# Altium schematic sheet backplane.SchDoc
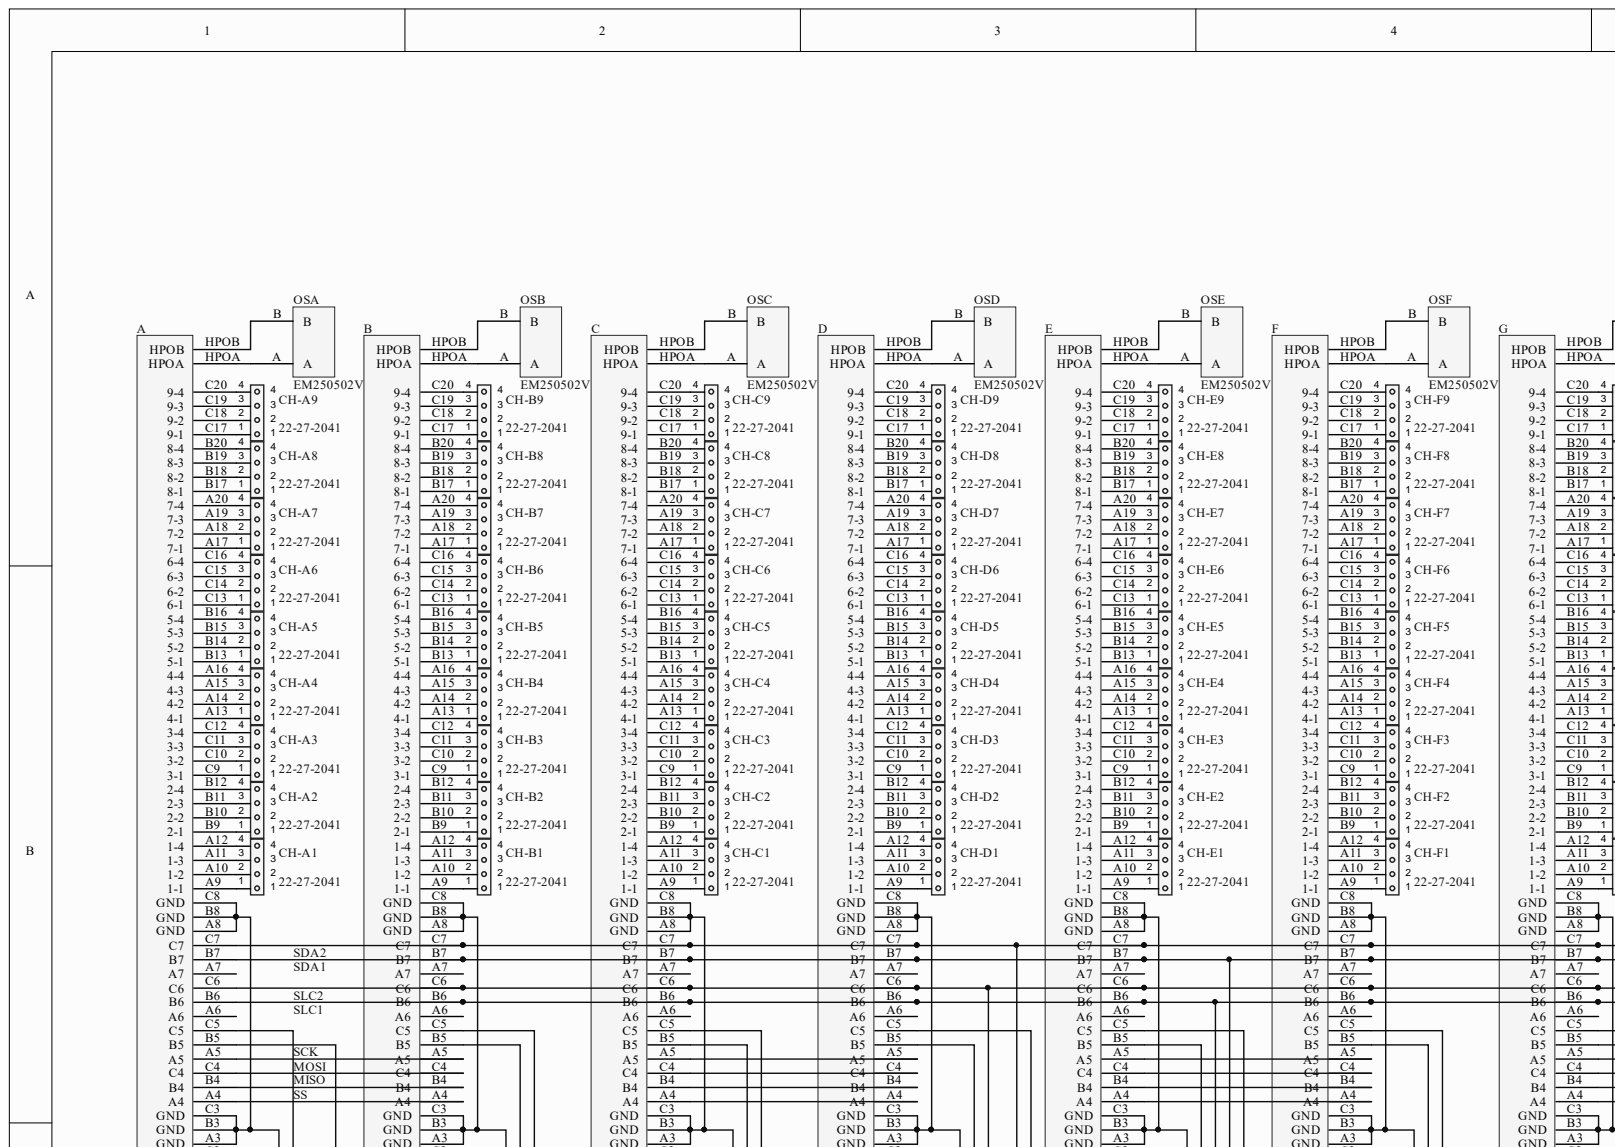
[diagram p0: backplane.SchDoc, top left]
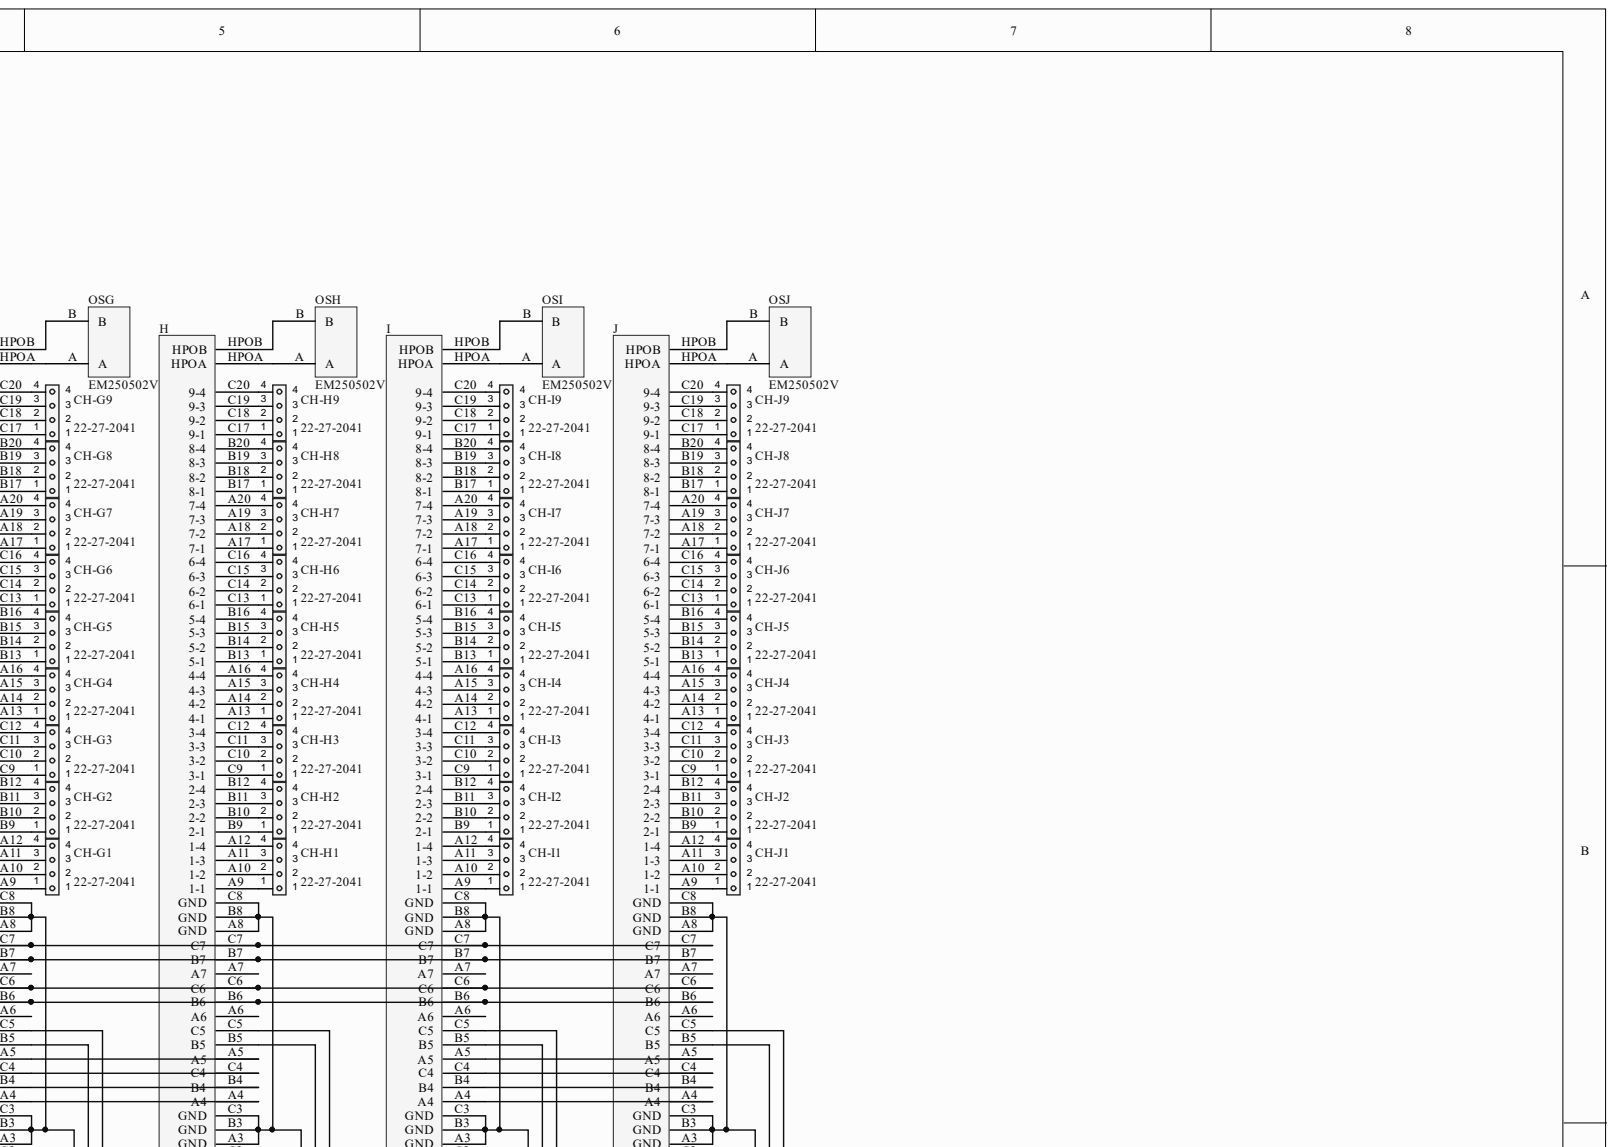
[diagram p1: backplane.SchDoc, top right]
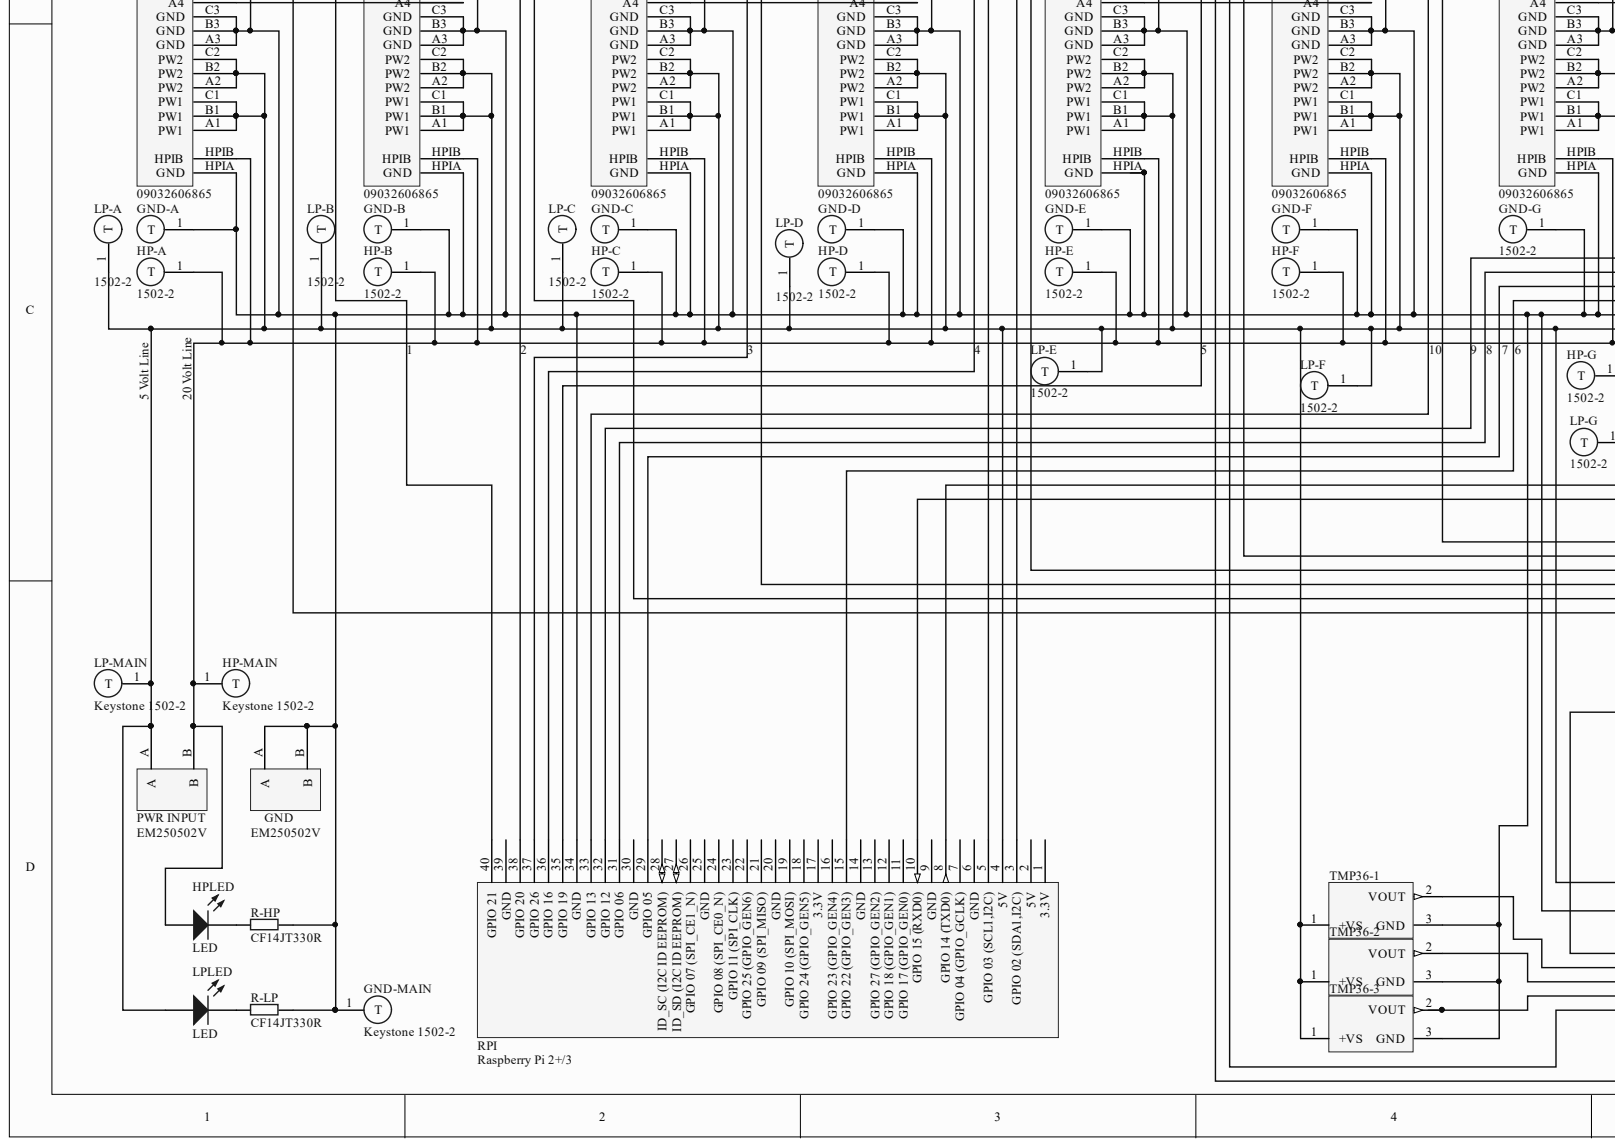
[diagram p2: backplane.SchDoc, bottom left]
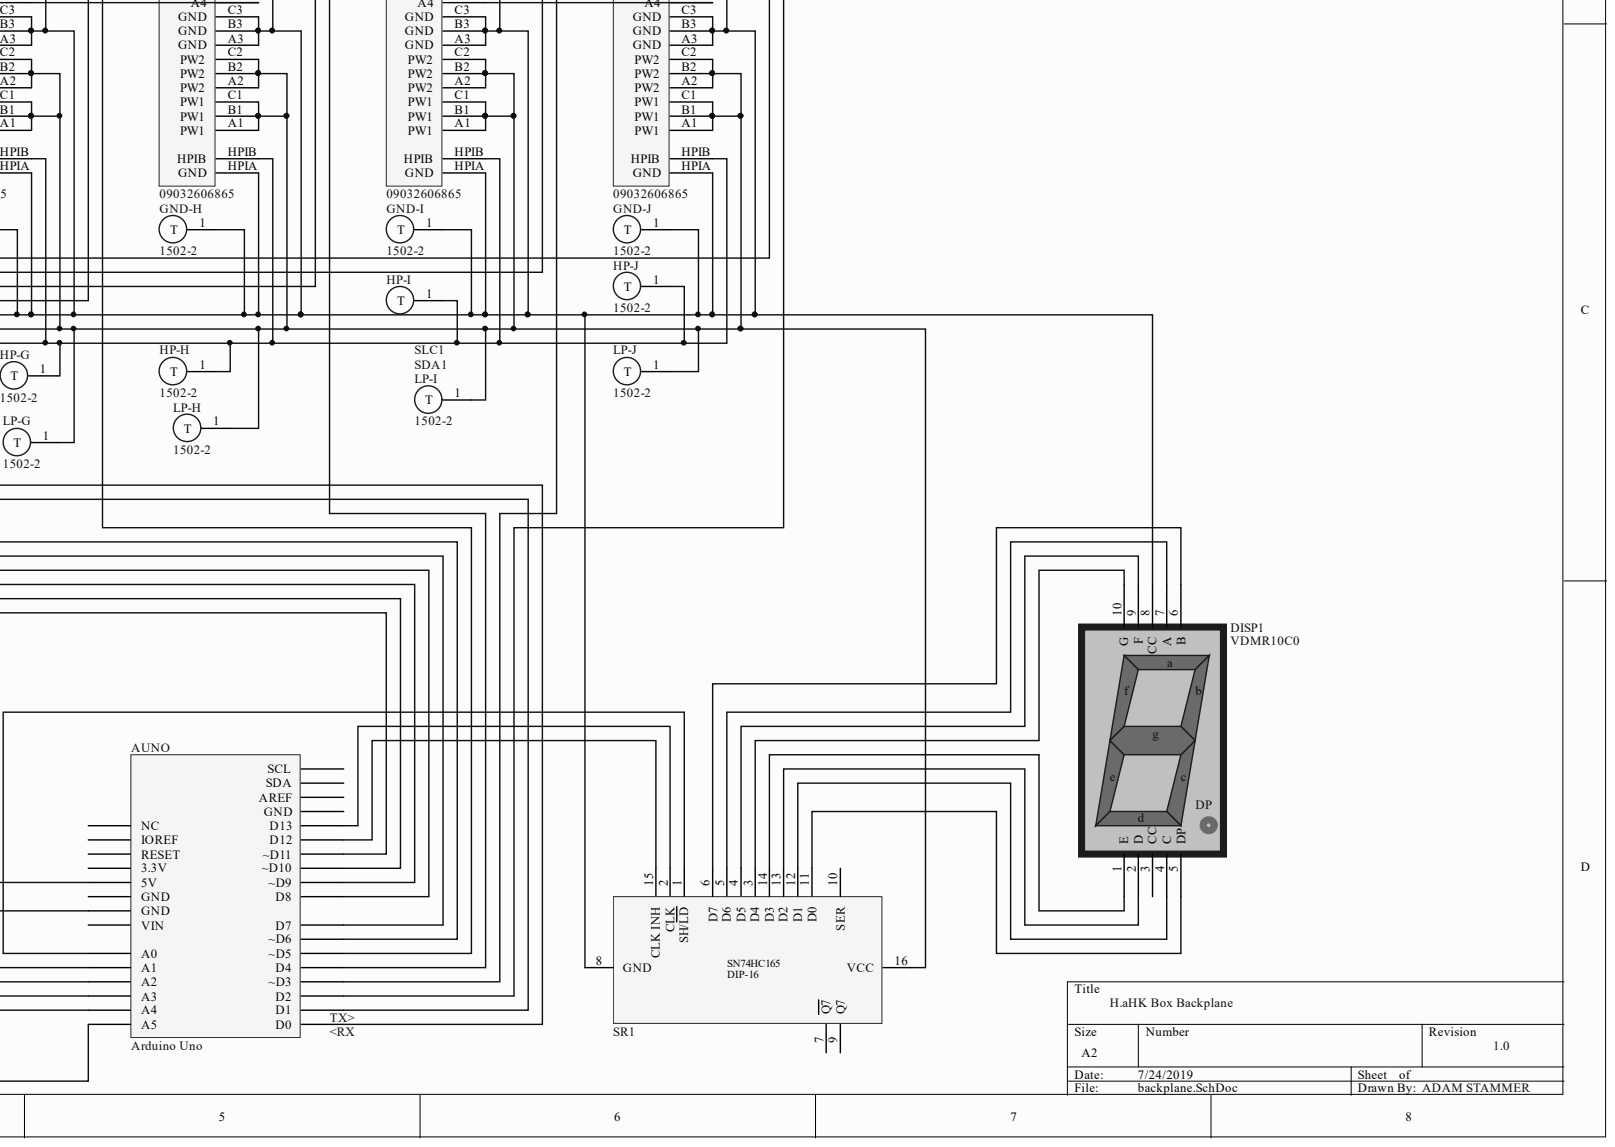
[diagram p3: backplane.SchDoc, bottom right]

## Parts
A  09032606865  [PCBComponent_1 - duplicate]
AUNO  Arduino Uno  [PCB-5e3n8s0ey5jqr2f9nncc-1]
B  09032606865  [PCBComponent_1 - duplicate]
C  09032606865  [PCBComponent_1 - duplicate]
CH-A1  22-27-2041  [PCB-kaiyohh0lgufhypq6v61-1]
CH-A2  22-27-2041  [PCB-kaiyohh0lgufhypq6v61-1]
CH-A3  22-27-2041  [PCB-kaiyohh0lgufhypq6v61-1]
CH-A4  22-27-2041  [PCB-kaiyohh0lgufhypq6v61-1]
CH-A5  22-27-2041  [PCB-kaiyohh0lgufhypq6v61-1]
CH-A6  22-27-2041  [PCB-kaiyohh0lgufhypq6v61-1]
CH-A7  22-27-2041  [PCB-kaiyohh0lgufhypq6v61-1]
CH-A8  22-27-2041  [PCB-kaiyohh0lgufhypq6v61-1]
CH-A9  22-27-2041  [PCB-kaiyohh0lgufhypq6v61-1]
CH-B1  22-27-2041  [PCB-kaiyohh0lgufhypq6v61-1]
CH-B2  22-27-2041  [PCB-kaiyohh0lgufhypq6v61-1]
CH-B3  22-27-2041  [PCB-kaiyohh0lgufhypq6v61-1]
CH-B4  22-27-2041  [PCB-kaiyohh0lgufhypq6v61-1]
CH-B5  22-27-2041  [PCB-kaiyohh0lgufhypq6v61-1]
CH-B6  22-27-2041  [PCB-kaiyohh0lgufhypq6v61-1]
CH-B7  22-27-2041  [PCB-kaiyohh0lgufhypq6v61-1]
CH-B8  22-27-2041  [PCB-kaiyohh0lgufhypq6v61-1]
CH-B9  22-27-2041  [PCB-kaiyohh0lgufhypq6v61-1]
CH-C1  22-27-2041  [PCB-kaiyohh0lgufhypq6v61-1]
CH-C2  22-27-2041  [PCB-kaiyohh0lgufhypq6v61-1]
CH-C3  22-27-2041  [PCB-kaiyohh0lgufhypq6v61-1]
CH-C4  22-27-2041  [PCB-kaiyohh0lgufhypq6v61-1]
CH-C5  22-27-2041  [PCB-kaiyohh0lgufhypq6v61-1]
CH-C6  22-27-2041  [PCB-kaiyohh0lgufhypq6v61-1]
CH-C7  22-27-2041  [PCB-kaiyohh0lgufhypq6v61-1]
CH-C8  22-27-2041  [PCB-kaiyohh0lgufhypq6v61-1]
CH-C9  22-27-2041  [PCB-kaiyohh0lgufhypq6v61-1]
CH-D1  22-27-2041  [PCB-kaiyohh0lgufhypq6v61-1]
CH-D2  22-27-2041  [PCB-kaiyohh0lgufhypq6v61-1]
CH-D3  22-27-2041  [PCB-kaiyohh0lgufhypq6v61-1]
CH-D4  22-27-2041  [PCB-kaiyohh0lgufhypq6v61-1]
CH-D5  22-27-2041  [PCB-kaiyohh0lgufhypq6v61-1]
CH-D6  22-27-2041  [PCB-kaiyohh0lgufhypq6v61-1]
CH-D7  22-27-2041  [PCB-kaiyohh0lgufhypq6v61-1]
CH-D8  22-27-2041  [PCB-kaiyohh0lgufhypq6v61-1]
CH-D9  22-27-2041  [PCB-kaiyohh0lgufhypq6v61-1]
CH-E1  22-27-2041  [PCB-kaiyohh0lgufhypq6v61-1]
CH-E2  22-27-2041  [PCB-kaiyohh0lgufhypq6v61-1]
CH-E3  22-27-2041  [PCB-kaiyohh0lgufhypq6v61-1]
CH-E4  22-27-2041  [PCB-kaiyohh0lgufhypq6v61-1]
CH-E5  22-27-2041  [PCB-kaiyohh0lgufhypq6v61-1]
CH-E6  22-27-2041  [PCB-kaiyohh0lgufhypq6v61-1]
CH-E7  22-27-2041  [PCB-kaiyohh0lgufhypq6v61-1]
CH-E8  22-27-2041  [PCB-kaiyohh0lgufhypq6v61-1]
CH-E9  22-27-2041  [PCB-kaiyohh0lgufhypq6v61-1]
CH-F1  22-27-2041  [PCB-kaiyohh0lgufhypq6v61-1]
CH-F2  22-27-2041  [PCB-kaiyohh0lgufhypq6v61-1]
CH-F3  22-27-2041  [PCB-kaiyohh0lgufhypq6v61-1]
CH-F4  22-27-2041  [PCB-kaiyohh0lgufhypq6v61-1]
CH-F5  22-27-2041  [PCB-kaiyohh0lgufhypq6v61-1]
CH-F6  22-27-2041  [PCB-kaiyohh0lgufhypq6v61-1]
CH-F7  22-27-2041  [PCB-kaiyohh0lgufhypq6v61-1]
CH-F8  22-27-2041  [PCB-kaiyohh0lgufhypq6v61-1]
CH-F9  22-27-2041  [PCB-kaiyohh0lgufhypq6v61-1]
CH-G1  22-27-2041  [PCB-kaiyohh0lgufhypq6v61-1]
CH-G2  22-27-2041  [PCB-kaiyohh0lgufhypq6v61-1]
CH-G3  22-27-2041  [PCB-kaiyohh0lgufhypq6v61-1]
CH-G4  22-27-2041  [PCB-kaiyohh0lgufhypq6v61-1]
CH-G5  22-27-2041  [PCB-kaiyohh0lgufhypq6v61-1]
CH-G6  22-27-2041  [PCB-kaiyohh0lgufhypq6v61-1]
CH-G7  22-27-2041  [PCB-kaiyohh0lgufhypq6v61-1]
CH-G8  22-27-2041  [PCB-kaiyohh0lgufhypq6v61-1]
CH-G9  22-27-2041  [PCB-kaiyohh0lgufhypq6v61-1]
CH-H1  22-27-2041  [PCB-kaiyohh0lgufhypq6v61-1]
CH-H2  22-27-2041  [PCB-kaiyohh0lgufhypq6v61-1]
CH-H3  22-27-2041  [PCB-kaiyohh0lgufhypq6v61-1]
CH-H4  22-27-2041  [PCB-kaiyohh0lgufhypq6v61-1]
CH-H5  22-27-2041  [PCB-kaiyohh0lgufhypq6v61-1]
CH-H6  22-27-2041  [PCB-kaiyohh0lgufhypq6v61-1]
CH-H7  22-27-2041  [PCB-kaiyohh0lgufhypq6v61-1]
CH-H8  22-27-2041  [PCB-kaiyohh0lgufhypq6v61-1]
CH-H9  22-27-2041  [PCB-kaiyohh0lgufhypq6v61-1]
CH-I1  22-27-2041  [PCB-kaiyohh0lgufhypq6v61-1]
CH-I2  22-27-2041  [PCB-kaiyohh0lgufhypq6v61-1]
CH-I3  22-27-2041  [PCB-kaiyohh0lgufhypq6v61-1]
CH-I4  22-27-2041  [PCB-kaiyohh0lgufhypq6v61-1]
CH-I5  22-27-2041  [PCB-kaiyohh0lgufhypq6v61-1]
CH-I6  22-27-2041  [PCB-kaiyohh0lgufhypq6v61-1]
CH-I7  22-27-2041  [PCB-kaiyohh0lgufhypq6v61-1]
CH-I8  22-27-2041  [PCB-kaiyohh0lgufhypq6v61-1]
CH-I9  22-27-2041  [PCB-kaiyohh0lgufhypq6v61-1]
CH-J1  22-27-2041  [PCB-kaiyohh0lgufhypq6v61-1]
CH-J2  22-27-2041  [PCB-kaiyohh0lgufhypq6v61-1]
CH-J3  22-27-2041  [PCB-kaiyohh0lgufhypq6v61-1]
CH-J4  22-27-2041  [PCB-kaiyohh0lgufhypq6v61-1]
CH-J5  22-27-2041  [PCB-kaiyohh0lgufhypq6v61-1]
CH-J6  22-27-2041  [PCB-kaiyohh0lgufhypq6v61-1]
CH-J7  22-27-2041  [PCB-kaiyohh0lgufhypq6v61-1]
CH-J8  22-27-2041  [PCB-kaiyohh0lgufhypq6v61-1]
CH-J9  22-27-2041  [PCB-kaiyohh0lgufhypq6v61-1]
D  09032606865  [PCBComponent_1 - duplicate]
DISP1  VDMR10C0  [PCBComponent_1 - duplicate]
E  09032606865  [PCBComponent_1 - duplicate]
F  09032606865  [PCBComponent_1 - duplicate]
G  09032606865  [PCBComponent_1 - duplicate]
GND  EM250502V  [PCB-u2v43o1ftwqs3duy389i-1]
GND-A  1502-2  [PCB-3pxxqie2fct8emy2m3uy-1]
GND-B  1502-2  [PCB-3pxxqie2fct8emy2m3uy-1]
GND-C  1502-2  [PCB-3pxxqie2fct8emy2m3uy-1]
GND-D  1502-2  [PCB-3pxxqie2fct8emy2m3uy-1]
GND-E  1502-2  [PCB-3pxxqie2fct8emy2m3uy-1]
GND-F  1502-2  [PCB-3pxxqie2fct8emy2m3uy-1]
GND-G  1502-2  [PCB-3pxxqie2fct8emy2m3uy-1]
GND-H  1502-2  [PCB-3pxxqie2fct8emy2m3uy-1]
GND-I  1502-2  [PCB-3pxxqie2fct8emy2m3uy-1]
GND-J  1502-2  [PCB-3pxxqie2fct8emy2m3uy-1]
GND-MAIN  Keystone 1502-2  Manufacturer Part Number: 1502-2  [PCB-3pxxqie2fct8emy2m3uy-1]
H  09032606865  [PCBComponent_1 - duplicate]
HP-A  1502-2  [PCB-3pxxqie2fct8emy2m3uy-1]
HP-B  1502-2  [PCB-3pxxqie2fct8emy2m3uy-1]
HP-C  1502-2  [PCB-3pxxqie2fct8emy2m3uy-1]
HP-D  1502-2  [PCB-3pxxqie2fct8emy2m3uy-1]
HP-E  1502-2  [PCB-3pxxqie2fct8emy2m3uy-1]
HP-F  1502-2  [PCB-3pxxqie2fct8emy2m3uy-1]
HP-G  1502-2  [PCB-3pxxqie2fct8emy2m3uy-1]
HP-H  1502-2  [PCB-3pxxqie2fct8emy2m3uy-1]
HP-I  1502-2  [PCB-3pxxqie2fct8emy2m3uy-1]
HP-J  1502-2  [PCB-3pxxqie2fct8emy2m3uy-1]
HP-MAIN  Keystone 1502-2  Manufacturer Part Number: 1502-2  [PCB-3pxxqie2fct8emy2m3uy-1]
HPLED  LED  [PCB-t8a8bkv5t5yzrq5f3vco-1]
I  09032606865  [PCBComponent_1 - duplicate]
J  09032606865  [PCBComponent_1 - duplicate]
LP-A  1502-2  [PCB-3pxxqie2fct8emy2m3uy-1]
LP-B  1502-2  [PCB-3pxxqie2fct8emy2m3uy-1]
LP-C  1502-2  [PCB-3pxxqie2fct8emy2m3uy-1]
LP-D  1502-2  [PCB-3pxxqie2fct8emy2m3uy-1]
LP-E  1502-2  [PCB-3pxxqie2fct8emy2m3uy-1]
LP-F  1502-2  [PCB-3pxxqie2fct8emy2m3uy-1]
LP-G  1502-2  [PCB-3pxxqie2fct8emy2m3uy-1]
LP-H  1502-2  [PCB-3pxxqie2fct8emy2m3uy-1]
LP-I  1502-2  [PCB-3pxxqie2fct8emy2m3uy-1]
LP-J  1502-2  [PCB-3pxxqie2fct8emy2m3uy-1]
LP-MAIN  Keystone 1502-2  Manufacturer Part Number: 1502-2  [PCB-3pxxqie2fct8emy2m3uy-1]
LPLED  LED  [PCB-t8a8bkv5t5yzrq5f3vco-1]
OSA  EM250502V  [PCB-u2v43o1ftwqs3duy389i-1]
OSB  EM250502V  [PCB-u2v43o1ftwqs3duy389i-1]
OSC  EM250502V  [PCB-u2v43o1ftwqs3duy389i-1]
OSD  EM250502V  [PCB-u2v43o1ftwqs3duy389i-1]
OSE  EM250502V  [PCB-u2v43o1ftwqs3duy389i-1]
OSF  EM250502V  [PCB-u2v43o1ftwqs3duy389i-1]
OSG  EM250502V  [PCB-u2v43o1ftwqs3duy389i-1]
OSH  EM250502V  [PCB-u2v43o1ftwqs3duy389i-1]
OSI  EM250502V  [PCB-u2v43o1ftwqs3duy389i-1]
OSJ  EM250502V  [PCB-u2v43o1ftwqs3duy389i-1]
PWR_INPUT  EM250502V  [PCB-u2v43o1ftwqs3duy389i-1]
R-HP  CF14JT330R  [PCB-2034312-1]
R-LP  CF14JT330R  [PCB-2034312-1]
RPI  Raspberry Pi 2+/3  [Header Only]
SR1  SYM-384ff145283ee788-5  [PCB-v75ktvxv322zbbdwrb5y-1]  (value not drawn)
TMP36-1  TMP36GT9  [ADI-T-3]  (value not drawn)
TMP36-2  TMP36GT9  [ADI-T-3]  (value not drawn)
TMP36-3  TMP36GT9  [ADI-T-3]  (value not drawn)

## Nets
NetA_A1: A.A1(PW1) A.A2(PW2) A.B1(PW1) A.B2(PW2) A.C1(PW1) A.C2(PW2) AUNO.5V B.A1(PW1) B.A2(PW2) B.B1(PW1) B.B2(PW2) B.C1(PW1) B.C2(PW2) C.A1(PW1) C.A2(PW2) C.B1(PW1) C.B2(PW2) C.C1(PW1) C.C2(PW2) D.A1(PW1) D.A2(PW2) D.B1(PW1) D.B2(PW2) D.C1(PW1) D.C2(PW2) E.A1(PW1) E.A2(PW2) E.B1(PW1) E.B2(PW2) E.C1(PW1) E.C2(PW2) F.A1(PW1) F.A2(PW2) F.B1(PW1) F.B2(PW2) F.C1(PW1) F.C2(PW2) G.A1(PW1) G.A2(PW2) G.B1(PW1) G.B2(PW2) G.C1(PW1) G.C2(PW2) H.A1(PW1) H.A2(PW2) H.B1(PW1) H.B2(PW2) H.C1(PW1) H.C2(PW2) I.A1(PW1) I.A2(PW2) I.B1(PW1) I.B2(PW2) I.C1(PW1) I.C2(PW2) J.A1(PW1) J.A2(PW2) J.B1(PW1) J.B2(PW2) J.C1(PW1) J.C2(PW2) LP-A.1 LP-B.1 LP-C.1 LP-D.1 LP-E.1 LP-F.1 LP-G.1 LP-H.1 LP-I.1 LP-J.1 LP-MAIN.1 LPLED.1 PWR_INPUT.A RPI.4(5V) SR1.16(VCC) TMP36-1.1 TMP36-2.1 TMP36-3.1
NetA_A3: A.A3(GND) A.A8(GND) A.B3(GND) A.B8(GND) A.C3(GND) A.C8(GND) A.HPIA(GND) AUNO.GND2(GND) B.A3(GND) B.A8(GND) B.B3(GND) B.B8(GND) B.C3(GND) B.C8(GND) B.HPIA(GND) C.A3(GND) C.A8(GND) C.B3(GND) C.B8(GND) C.C3(GND) C.C8(GND) C.HPIA(GND) D.A3(GND) D.A8(GND) D.B3(GND) D.B8(GND) D.C3(GND) D.C8(GND) D.HPIA(GND) DISP1.8(CC) E.A3(GND) E.A8(GND) E.B3(GND) E.B8(GND) E.C3(GND) E.C8(GND) E.HPIA(GND) F.A3(GND) F.A8(GND) F.B3(GND) F.B8(GND) F.C3(GND) F.C8(GND) F.HPIA(GND) G.A3(GND) G.A8(GND) G.B3(GND) G.B8(GND) G.C3(GND) G.C8(GND) G.HPIA(GND) GND.A GND.B GND-A.1 GND-B.1 GND-C.1 GND-D.1 GND-E.1 GND-F.1 GND-G.1 GND-H.1 GND-I.1 GND-J.1 GND-MAIN.1 H.A3(GND) H.A8(GND) H.B3(GND) H.B8(GND) H.C3(GND) H.C8(GND) H.HPIA(GND) I.A3(GND) I.A8(GND) I.B3(GND) I.B8(GND) I.C3(GND) I.C8(GND) I.HPIA(GND) J.A3(GND) J.A8(GND) J.B3(GND) J.B8(GND) J.C3(GND) J.C8(GND) J.HPIA(GND) R-HP.2 R-LP.2 RPI.34(GND) SR1.8(GND) TMP36-1.3 TMP36-2.3 TMP36-3.3
NetA_A4: A.A4 B.A4
NetA_A5: A.A5 B.A5
NetA_A9: A.A9(1-1) CH-A1.1
NetA_A10: A.A10(1-2) CH-A1.2
NetA_A11: A.A11(1-3) CH-A1.3
NetA_A12: A.A12(1-4) CH-A1.4
NetA_A13: A.A13(4-1) CH-A4.1
NetA_A14: A.A14(4-2) CH-A4.2
NetA_A15: A.A15(4-3) CH-A4.3
NetA_A16: A.A16(4-4) CH-A4.4
NetA_A17: A.A17(7-1) CH-A7.1
NetA_A18: A.A18(7-2) CH-A7.2
NetA_A19: A.A19(7-3) CH-A7.3
NetA_A20: A.A20(7-4) CH-A7.4
NetA_B4: A.B4 B.B4
NetA_B5: A.B5 RPI.40(GPIO_21)
NetA_B6: A.B6 AUNO.A5 B.B6 C.B6 D.B6 E.B6 F.B6 G.B6 H.B6 I.B6 J.B6
NetA_B7: A.B7 AUNO.A4 B.B7 C.B7 D.B7 E.B7 F.B7 G.B7 H.B7 I.B7 J.B7
NetA_B9: A.B9(2-1) CH-A2.1
NetA_B10: A.B10(2-2) CH-A2.2
NetA_B11: A.B11(2-3) CH-A2.3
NetA_B12: A.B12(2-4) CH-A2.4
NetA_B13: A.B13(5-1) CH-A5.1
NetA_B14: A.B14(5-2) CH-A5.2
NetA_B15: A.B15(5-3) CH-A5.3
NetA_B16: A.B16(5-4) CH-A5.4
NetA_B17: A.B17(8-1) CH-A8.1
NetA_B18: A.B18(8-2) CH-A8.2
NetA_B19: A.B19(8-3) CH-A8.3
NetA_B20: A.B20(8-4) CH-A8.4
NetA_C4: A.C4 B.C4
NetA_C5: A.C5 AUNO.D11(~D11)
NetA_C6: A.C6 B.C6 C.C6 D.C6 E.C6 F.C6 G.C6 H.C6 I.C6 J.C6 RPI.5(GPIO_03_(SCL1,I2C))
NetA_C7: A.C7 B.C7 C.C7 D.C7 E.C7 F.C7 G.C7 H.C7 I.C7 J.C7 RPI.3(GPIO_02_(SDA1,I2C))
NetA_C9: A.C9(3-1) CH-A3.1
NetA_C10: A.C10(3-2) CH-A3.2
NetA_C11: A.C11(3-3) CH-A3.3
NetA_C12: A.C12(3-4) CH-A3.4
NetA_C13: A.C13(6-1) CH-A6.1
NetA_C14: A.C14(6-2) CH-A6.2
NetA_C15: A.C15(6-3) CH-A6.3
NetA_C16: A.C16(6-4) CH-A6.4
NetA_C17: A.C17(9-1) CH-A9.1
NetA_C18: A.C18(9-2) CH-A9.2
NetA_C19: A.C19(9-3) CH-A9.3
NetA_C20: A.C20(9-4) CH-A9.4
NetA_HPIB: A.HPIB B.HPIB C.HPIB D.HPIB E.HPIB F.HPIB G.HPIB H.HPIB HP-A.1 HP-B.1 HP-C.1 HP-D.1 HP-E.1 HP-F.1 HP-G.1 HP-H.1 HP-I.1 HP-J.1 HP-MAIN.1 HPLED.1 I.HPIB J.HPIB PWR_INPUT.B
NetA_HPOA: A.HPOA OSA.A
NetA_HPOB: A.HPOB OSA.B
NetAUNO_A0: AUNO.A0 SR1.1(SH/LD)
NetAUNO_A1: AUNO.A1 TMP36-1.2
NetAUNO_A2: AUNO.A2 TMP36-2.2
NetAUNO_A3: AUNO.A3 TMP36-3.2
NetAUNO_D0: AUNO.D0 RPI.8(GPIO_14_(TXD0))
NetAUNO_D1: AUNO.D1 RPI.10(GPIO_15_(RXD0))
NetAUNO_D2: AUNO.D2 J.C5
NetAUNO_D3: AUNO.D3(~D3) I.C5
NetAUNO_D4: AUNO.D4 H.C5
NetAUNO_D5: AUNO.D5(~D5) G.C5
NetAUNO_D6: AUNO.D6(~D6) F.C5
NetAUNO_D7: AUNO.D7 E.C5
NetAUNO_D8: AUNO.D8 D.C5
NetAUNO_D9: AUNO.D9(~D9) C.C5
NetAUNO_D10: AUNO.D10(~D10) B.C5
NetAUNO_D12: AUNO.D12 SR1.15(CLK_INH)
NetAUNO_D13: AUNO.D13 SR1.2(CLK)
NetB_A9: B.A9(1-1) CH-B1.1
NetB_A10: B.A10(1-2) CH-B1.2
NetB_A11: B.A11(1-3) CH-B1.3
NetB_A12: B.A12(1-4) CH-B1.4
NetB_A13: B.A13(4-1) CH-B4.1
NetB_A14: B.A14(4-2) CH-B4.2
NetB_A15: B.A15(4-3) CH-B4.3
NetB_A16: B.A16(4-4) CH-B4.4
NetB_A17: B.A17(7-1) CH-B7.1
NetB_A18: B.A18(7-2) CH-B7.2
NetB_A19: B.A19(7-3) CH-B7.3
NetB_A20: B.A20(7-4) CH-B7.4
NetB_B5: B.B5 RPI.38(GPIO_20)
NetB_B9: B.B9(2-1) CH-B2.1
NetB_B10: B.B10(2-2) CH-B2.2
NetB_B11: B.B11(2-3) CH-B2.3
NetB_B12: B.B12(2-4) CH-B2.4
NetB_B13: B.B13(5-1) CH-B5.1
NetB_B14: B.B14(5-2) CH-B5.2
NetB_B15: B.B15(5-3) CH-B5.3
NetB_B16: B.B16(5-4) CH-B5.4
NetB_B17: B.B17(8-1) CH-B8.1
NetB_B18: B.B18(8-2) CH-B8.2
NetB_B19: B.B19(8-3) CH-B8.3
NetB_B20: B.B20(8-4) CH-B8.4
NetB_C9: B.C9(3-1) CH-B3.1
NetB_C10: B.C10(3-2) CH-B3.2
NetB_C11: B.C11(3-3) CH-B3.3
NetB_C12: B.C12(3-4) CH-B3.4
NetB_C13: B.C13(6-1) CH-B6.1
NetB_C14: B.C14(6-2) CH-B6.2
NetB_C15: B.C15(6-3) CH-B6.3
NetB_C16: B.C16(6-4) CH-B6.4
NetB_C17: B.C17(9-1) CH-B9.1
NetB_C18: B.C18(9-2) CH-B9.2
NetB_C19: B.C19(9-3) CH-B9.3
NetB_C20: B.C20(9-4) CH-B9.4
NetB_HPOA: B.HPOA OSB.A
NetB_HPOB: B.HPOB OSB.B
NetC_A4: C.A4 D.A4
NetC_A5: C.A5 D.A5
NetC_A9: C.A9(1-1) CH-C1.1
NetC_A10: C.A10(1-2) CH-C1.2
NetC_A11: C.A11(1-3) CH-C1.3
NetC_A12: C.A12(1-4) CH-C1.4
NetC_A13: C.A13(4-1) CH-C4.1
NetC_A14: C.A14(4-2) CH-C4.2
NetC_A15: C.A15(4-3) CH-C4.3
NetC_A16: C.A16(4-4) CH-C4.4
NetC_A17: C.A17(7-1) CH-C7.1
NetC_A18: C.A18(7-2) CH-C7.2
NetC_A19: C.A19(7-3) CH-C7.3
NetC_A20: C.A20(7-4) CH-C7.4
NetC_B4: C.B4 D.B4
NetC_B5: C.B5 RPI.37(GPIO_26)
NetC_B9: C.B9(2-1) CH-C2.1
NetC_B10: C.B10(2-2) CH-C2.2
NetC_B11: C.B11(2-3) CH-C2.3
NetC_B12: C.B12(2-4) CH-C2.4
NetC_B13: C.B13(5-1) CH-C5.1
NetC_B14: C.B14(5-2) CH-C5.2
NetC_B15: C.B15(5-3) CH-C5.3
NetC_B16: C.B16(5-4) CH-C5.4
NetC_B17: C.B17(8-1) CH-C8.1
NetC_B18: C.B18(8-2) CH-C8.2
NetC_B19: C.B19(8-3) CH-C8.3
NetC_B20: C.B20(8-4) CH-C8.4
NetC_C4: C.C4 D.C4
NetC_C9: C.C9(3-1) CH-C3.1
NetC_C10: C.C10(3-2) CH-C3.2
NetC_C11: C.C11(3-3) CH-C3.3
NetC_C12: C.C12(3-4) CH-C3.4
NetC_C13: C.C13(6-1) CH-C6.1
NetC_C14: C.C14(6-2) CH-C6.2
NetC_C15: C.C15(6-3) CH-C6.3
NetC_C16: C.C16(6-4) CH-C6.4
NetC_C17: C.C17(9-1) CH-C9.1
NetC_C18: C.C18(9-2) CH-C9.2
NetC_C19: C.C19(9-3) CH-C9.3
NetC_C20: C.C20(9-4) CH-C9.4
NetC_HPOA: C.HPOA OSC.A
NetC_HPOB: C.HPOB OSC.B
NetCH-D1_1: CH-D1.1 D.A9(1-1)
NetCH-D1_2: CH-D1.2 D.A10(1-2)
NetCH-D1_3: CH-D1.3 D.A11(1-3)
NetCH-D1_4: CH-D1.4 D.A12(1-4)
NetCH-D2_1: CH-D2.1 D.B9(2-1)
NetCH-D2_2: CH-D2.2 D.B10(2-2)
NetCH-D2_3: CH-D2.3 D.B11(2-3)
NetCH-D2_4: CH-D2.4 D.B12(2-4)
NetCH-D3_1: CH-D3.1 D.C9(3-1)
NetCH-D3_2: CH-D3.2 D.C10(3-2)
NetCH-D3_3: CH-D3.3 D.C11(3-3)
NetCH-D3_4: CH-D3.4 D.C12(3-4)
NetCH-D4_1: CH-D4.1 D.A13(4-1)
NetCH-D4_2: CH-D4.2 D.A14(4-2)
NetCH-D4_3: CH-D4.3 D.A15(4-3)
NetCH-D4_4: CH-D4.4 D.A16(4-4)
NetCH-D5_1: CH-D5.1 D.B13(5-1)
NetCH-D5_2: CH-D5.2 D.B14(5-2)
NetCH-D5_3: CH-D5.3 D.B15(5-3)
NetCH-D5_4: CH-D5.4 D.B16(5-4)
NetCH-D6_1: CH-D6.1 D.C13(6-1)
NetCH-D6_2: CH-D6.2 D.C14(6-2)
NetCH-D6_3: CH-D6.3 D.C15(6-3)
NetCH-D6_4: CH-D6.4 D.C16(6-4)
NetCH-D7_1: CH-D7.1 D.A17(7-1)
NetCH-D7_2: CH-D7.2 D.A18(7-2)
NetCH-D7_3: CH-D7.3 D.A19(7-3)
NetCH-D7_4: CH-D7.4 D.A20(7-4)
NetCH-D8_1: CH-D8.1 D.B17(8-1)
NetCH-D8_2: CH-D8.2 D.B18(8-2)
NetCH-D8_3: CH-D8.3 D.B19(8-3)
NetCH-D8_4: CH-D8.4 D.B20(8-4)
NetCH-D9_1: CH-D9.1 D.C17(9-1)
NetCH-D9_2: CH-D9.2 D.C18(9-2)
NetCH-D9_3: CH-D9.3 D.C19(9-3)
NetCH-D9_4: CH-D9.4 D.C20(9-4)
NetCH-E1_1: CH-E1.1 E.A9(1-1)
NetCH-E1_2: CH-E1.2 E.A10(1-2)
NetCH-E1_3: CH-E1.3 E.A11(1-3)
NetCH-E1_4: CH-E1.4 E.A12(1-4)
NetCH-E2_1: CH-E2.1 E.B9(2-1)
NetCH-E2_2: CH-E2.2 E.B10(2-2)
NetCH-E2_3: CH-E2.3 E.B11(2-3)
NetCH-E2_4: CH-E2.4 E.B12(2-4)
NetCH-E3_1: CH-E3.1 E.C9(3-1)
NetCH-E3_2: CH-E3.2 E.C10(3-2)
NetCH-E3_3: CH-E3.3 E.C11(3-3)
NetCH-E3_4: CH-E3.4 E.C12(3-4)
NetCH-E4_1: CH-E4.1 E.A13(4-1)
NetCH-E4_2: CH-E4.2 E.A14(4-2)
NetCH-E4_3: CH-E4.3 E.A15(4-3)
NetCH-E4_4: CH-E4.4 E.A16(4-4)
NetCH-E5_1: CH-E5.1 E.B13(5-1)
NetCH-E5_2: CH-E5.2 E.B14(5-2)
NetCH-E5_3: CH-E5.3 E.B15(5-3)
NetCH-E5_4: CH-E5.4 E.B16(5-4)
NetCH-E6_1: CH-E6.1 E.C13(6-1)
NetCH-E6_2: CH-E6.2 E.C14(6-2)
NetCH-E6_3: CH-E6.3 E.C15(6-3)
NetCH-E6_4: CH-E6.4 E.C16(6-4)
NetCH-E7_1: CH-E7.1 E.A17(7-1)
NetCH-E7_2: CH-E7.2 E.A18(7-2)
NetCH-E7_3: CH-E7.3 E.A19(7-3)
NetCH-E7_4: CH-E7.4 E.A20(7-4)
NetCH-E8_1: CH-E8.1 E.B17(8-1)
NetCH-E8_2: CH-E8.2 E.B18(8-2)
NetCH-E8_3: CH-E8.3 E.B19(8-3)
NetCH-E8_4: CH-E8.4 E.B20(8-4)
NetCH-E9_1: CH-E9.1 E.C17(9-1)
NetCH-E9_2: CH-E9.2 E.C18(9-2)
NetCH-E9_3: CH-E9.3 E.C19(9-3)
NetCH-E9_4: CH-E9.4 E.C20(9-4)
NetCH-F1_1: CH-F1.1 F.A9(1-1)
NetCH-F1_2: CH-F1.2 F.A10(1-2)
NetCH-F1_3: CH-F1.3 F.A11(1-3)
NetCH-F1_4: CH-F1.4 F.A12(1-4)
NetCH-F2_1: CH-F2.1 F.B9(2-1)
NetCH-F2_2: CH-F2.2 F.B10(2-2)
NetCH-F2_3: CH-F2.3 F.B11(2-3)
NetCH-F2_4: CH-F2.4 F.B12(2-4)
NetCH-F3_1: CH-F3.1 F.C9(3-1)
NetCH-F3_2: CH-F3.2 F.C10(3-2)
NetCH-F3_3: CH-F3.3 F.C11(3-3)
NetCH-F3_4: CH-F3.4 F.C12(3-4)
NetCH-F4_1: CH-F4.1 F.A13(4-1)
NetCH-F4_2: CH-F4.2 F.A14(4-2)
NetCH-F4_3: CH-F4.3 F.A15(4-3)
NetCH-F4_4: CH-F4.4 F.A16(4-4)
NetCH-F5_1: CH-F5.1 F.B13(5-1)
NetCH-F5_2: CH-F5.2 F.B14(5-2)
NetCH-F5_3: CH-F5.3 F.B15(5-3)
NetCH-F5_4: CH-F5.4 F.B16(5-4)
NetCH-F6_1: CH-F6.1 F.C13(6-1)
NetCH-F6_2: CH-F6.2 F.C14(6-2)
NetCH-F6_3: CH-F6.3 F.C15(6-3)
NetCH-F6_4: CH-F6.4 F.C16(6-4)
NetCH-F7_1: CH-F7.1 F.A17(7-1)
NetCH-F7_2: CH-F7.2 F.A18(7-2)
NetCH-F7_3: CH-F7.3 F.A19(7-3)
NetCH-F7_4: CH-F7.4 F.A20(7-4)
NetCH-F8_1: CH-F8.1 F.B17(8-1)
NetCH-F8_2: CH-F8.2 F.B18(8-2)
NetCH-F8_3: CH-F8.3 F.B19(8-3)
NetCH-F8_4: CH-F8.4 F.B20(8-4)
NetCH-F9_1: CH-F9.1 F.C17(9-1)
NetCH-F9_2: CH-F9.2 F.C18(9-2)
NetCH-F9_3: CH-F9.3 F.C19(9-3)
NetCH-F9_4: CH-F9.4 F.C20(9-4)
NetCH-G1_1: CH-G1.1 G.A9(1-1)
NetCH-G1_2: CH-G1.2 G.A10(1-2)
NetCH-G1_3: CH-G1.3 G.A11(1-3)
NetCH-G1_4: CH-G1.4 G.A12(1-4)
NetCH-G2_1: CH-G2.1 G.B9(2-1)
NetCH-G2_2: CH-G2.2 G.B10(2-2)
NetCH-G2_3: CH-G2.3 G.B11(2-3)
NetCH-G2_4: CH-G2.4 G.B12(2-4)
NetCH-G3_1: CH-G3.1 G.C9(3-1)
NetCH-G3_2: CH-G3.2 G.C10(3-2)
NetCH-G3_3: CH-G3.3 G.C11(3-3)
NetCH-G3_4: CH-G3.4 G.C12(3-4)
NetCH-G4_1: CH-G4.1 G.A13(4-1)
NetCH-G4_2: CH-G4.2 G.A14(4-2)
NetCH-G4_3: CH-G4.3 G.A15(4-3)
NetCH-G4_4: CH-G4.4 G.A16(4-4)
NetCH-G5_1: CH-G5.1 G.B13(5-1)
NetCH-G5_2: CH-G5.2 G.B14(5-2)
NetCH-G5_3: CH-G5.3 G.B15(5-3)
NetCH-G5_4: CH-G5.4 G.B16(5-4)
NetCH-G6_1: CH-G6.1 G.C13(6-1)
NetCH-G6_2: CH-G6.2 G.C14(6-2)
NetCH-G6_3: CH-G6.3 G.C15(6-3)
NetCH-G6_4: CH-G6.4 G.C16(6-4)
NetCH-G7_1: CH-G7.1 G.A17(7-1)
NetCH-G7_2: CH-G7.2 G.A18(7-2)
NetCH-G7_3: CH-G7.3 G.A19(7-3)
NetCH-G7_4: CH-G7.4 G.A20(7-4)
NetCH-G8_1: CH-G8.1 G.B17(8-1)
NetCH-G8_2: CH-G8.2 G.B18(8-2)
NetCH-G8_3: CH-G8.3 G.B19(8-3)
NetCH-G8_4: CH-G8.4 G.B20(8-4)
NetCH-G9_1: CH-G9.1 G.C17(9-1)
NetCH-G9_2: CH-G9.2 G.C18(9-2)
NetCH-G9_3: CH-G9.3 G.C19(9-3)
NetCH-G9_4: CH-G9.4 G.C20(9-4)
NetCH-H1_1: CH-H1.1 H.A9(1-1)
NetCH-H1_2: CH-H1.2 H.A10(1-2)
NetCH-H1_3: CH-H1.3 H.A11(1-3)
NetCH-H1_4: CH-H1.4 H.A12(1-4)
NetCH-H2_1: CH-H2.1 H.B9(2-1)
NetCH-H2_2: CH-H2.2 H.B10(2-2)
NetCH-H2_3: CH-H2.3 H.B11(2-3)
NetCH-H2_4: CH-H2.4 H.B12(2-4)
NetCH-H3_1: CH-H3.1 H.C9(3-1)
NetCH-H3_2: CH-H3.2 H.C10(3-2)
NetCH-H3_3: CH-H3.3 H.C11(3-3)
NetCH-H3_4: CH-H3.4 H.C12(3-4)
NetCH-H4_1: CH-H4.1 H.A13(4-1)
NetCH-H4_2: CH-H4.2 H.A14(4-2)
NetCH-H4_3: CH-H4.3 H.A15(4-3)
NetCH-H4_4: CH-H4.4 H.A16(4-4)
NetCH-H5_1: CH-H5.1 H.B13(5-1)
NetCH-H5_2: CH-H5.2 H.B14(5-2)
NetCH-H5_3: CH-H5.3 H.B15(5-3)
NetCH-H5_4: CH-H5.4 H.B16(5-4)
NetCH-H6_1: CH-H6.1 H.C13(6-1)
NetCH-H6_2: CH-H6.2 H.C14(6-2)
NetCH-H6_3: CH-H6.3 H.C15(6-3)
NetCH-H6_4: CH-H6.4 H.C16(6-4)
NetCH-H7_1: CH-H7.1 H.A17(7-1)
NetCH-H7_2: CH-H7.2 H.A18(7-2)
NetCH-H7_3: CH-H7.3 H.A19(7-3)
NetCH-H7_4: CH-H7.4 H.A20(7-4)
NetCH-H8_1: CH-H8.1 H.B17(8-1)
NetCH-H8_2: CH-H8.2 H.B18(8-2)
NetCH-H8_3: CH-H8.3 H.B19(8-3)
NetCH-H8_4: CH-H8.4 H.B20(8-4)
NetCH-H9_1: CH-H9.1 H.C17(9-1)
NetCH-H9_2: CH-H9.2 H.C18(9-2)
NetCH-H9_3: CH-H9.3 H.C19(9-3)
NetCH-H9_4: CH-H9.4 H.C20(9-4)
NetCH-I1_1: CH-I1.1 I.A9(1-1)
NetCH-I1_2: CH-I1.2 I.A10(1-2)
NetCH-I1_3: CH-I1.3 I.A11(1-3)
NetCH-I1_4: CH-I1.4 I.A12(1-4)
NetCH-I2_1: CH-I2.1 I.B9(2-1)
NetCH-I2_2: CH-I2.2 I.B10(2-2)
NetCH-I2_3: CH-I2.3 I.B11(2-3)
NetCH-I2_4: CH-I2.4 I.B12(2-4)
NetCH-I3_1: CH-I3.1 I.C9(3-1)
NetCH-I3_2: CH-I3.2 I.C10(3-2)
NetCH-I3_3: CH-I3.3 I.C11(3-3)
NetCH-I3_4: CH-I3.4 I.C12(3-4)
NetCH-I4_1: CH-I4.1 I.A13(4-1)
NetCH-I4_2: CH-I4.2 I.A14(4-2)
NetCH-I4_3: CH-I4.3 I.A15(4-3)
NetCH-I4_4: CH-I4.4 I.A16(4-4)
NetCH-I5_1: CH-I5.1 I.B13(5-1)
NetCH-I5_2: CH-I5.2 I.B14(5-2)
NetCH-I5_3: CH-I5.3 I.B15(5-3)
NetCH-I5_4: CH-I5.4 I.B16(5-4)
NetCH-I6_1: CH-I6.1 I.C13(6-1)
NetCH-I6_2: CH-I6.2 I.C14(6-2)
NetCH-I6_3: CH-I6.3 I.C15(6-3)
NetCH-I6_4: CH-I6.4 I.C16(6-4)
NetCH-I7_1: CH-I7.1 I.A17(7-1)
NetCH-I7_2: CH-I7.2 I.A18(7-2)
NetCH-I7_3: CH-I7.3 I.A19(7-3)
NetCH-I7_4: CH-I7.4 I.A20(7-4)
NetCH-I8_1: CH-I8.1 I.B17(8-1)
NetCH-I8_2: CH-I8.2 I.B18(8-2)
NetCH-I8_3: CH-I8.3 I.B19(8-3)
NetCH-I8_4: CH-I8.4 I.B20(8-4)
NetCH-I9_1: CH-I9.1 I.C17(9-1)
NetCH-I9_2: CH-I9.2 I.C18(9-2)
NetCH-I9_3: CH-I9.3 I.C19(9-3)
NetCH-I9_4: CH-I9.4 I.C20(9-4)
NetCH-J1_1: CH-J1.1 J.A9(1-1)
NetCH-J1_2: CH-J1.2 J.A10(1-2)
NetCH-J1_3: CH-J1.3 J.A11(1-3)
NetCH-J1_4: CH-J1.4 J.A12(1-4)
NetCH-J2_1: CH-J2.1 J.B9(2-1)
NetCH-J2_2: CH-J2.2 J.B10(2-2)
NetCH-J2_3: CH-J2.3 J.B11(2-3)
NetCH-J2_4: CH-J2.4 J.B12(2-4)
NetCH-J3_1: CH-J3.1 J.C9(3-1)
NetCH-J3_2: CH-J3.2 J.C10(3-2)
NetCH-J3_3: CH-J3.3 J.C11(3-3)
NetCH-J3_4: CH-J3.4 J.C12(3-4)
NetCH-J4_1: CH-J4.1 J.A13(4-1)
NetCH-J4_2: CH-J4.2 J.A14(4-2)
NetCH-J4_3: CH-J4.3 J.A15(4-3)
NetCH-J4_4: CH-J4.4 J.A16(4-4)
NetCH-J5_1: CH-J5.1 J.B13(5-1)
NetCH-J5_2: CH-J5.2 J.B14(5-2)
NetCH-J5_3: CH-J5.3 J.B15(5-3)
NetCH-J5_4: CH-J5.4 J.B16(5-4)
NetCH-J6_1: CH-J6.1 J.C13(6-1)
NetCH-J6_2: CH-J6.2 J.C14(6-2)
NetCH-J6_3: CH-J6.3 J.C15(6-3)
NetCH-J6_4: CH-J6.4 J.C16(6-4)
NetCH-J7_1: CH-J7.1 J.A17(7-1)
NetCH-J7_2: CH-J7.2 J.A18(7-2)
NetCH-J7_3: CH-J7.3 J.A19(7-3)
NetCH-J7_4: CH-J7.4 J.A20(7-4)
NetCH-J8_1: CH-J8.1 J.B17(8-1)
NetCH-J8_2: CH-J8.2 J.B18(8-2)
NetCH-J8_3: CH-J8.3 J.B19(8-3)
NetCH-J8_4: CH-J8.4 J.B20(8-4)
NetCH-J9_1: CH-J9.1 J.C17(9-1)
NetCH-J9_2: CH-J9.2 J.C18(9-2)
NetCH-J9_3: CH-J9.3 J.C19(9-3)
NetCH-J9_4: CH-J9.4 J.C20(9-4)
NetD_B5: D.B5 RPI.36(GPIO_16)
NetD_HPOA: D.HPOA OSD.A
NetD_HPOB: D.HPOB OSD.B
NetDISP1_1: DISP1.1(E) SR1.14(D3)
NetDISP1_2: DISP1.2(D) SR1.13(D2)
NetDISP1_4: DISP1.4(C) SR1.12(D1)
NetDISP1_5: DISP1.5(DP) SR1.11(D0)
NetDISP1_6: DISP1.6(B) SR1.6(D7)
NetDISP1_7: DISP1.7(A) SR1.5(D6)
NetDISP1_9: DISP1.9(F) SR1.4(D5)
NetDISP1_10: DISP1.10(G) SR1.3(D4)
NetE_A4: E.A4 F.A4
NetE_A5: E.A5 F.A5
NetE_B4: E.B4 F.B4
NetE_B5: E.B5 RPI.35(GPIO_19)
NetE_C4: E.C4 F.C4
NetE_HPOA: E.HPOA OSE.A
NetE_HPOB: E.HPOB OSE.B
NetF_B5: F.B5 RPI.33(GPIO_13)
NetF_HPOA: F.HPOA OSF.A
NetF_HPOB: F.HPOB OSF.B
NetG_A4: G.A4 H.A4
NetG_A5: G.A5 H.A5
NetG_B4: G.B4 H.B4
NetG_B5: G.B5 RPI.15(GPIO_22_(GPIO_GEN3))
NetG_C4: G.C4 H.C4
NetG_HPOA: G.HPOA OSG.A
NetG_HPOB: G.HPOB OSG.B
NetH_B5: H.B5 RPI.29(GPIO_05)
NetH_HPOA: H.HPOA OSH.A
NetH_HPOB: H.HPOB OSH.B
NetHPLED_2: HPLED.2 R-HP.1
NetI_A4: I.A4 J.A4
NetI_A5: I.A5 J.A5
NetI_B4: I.B4 J.B4
NetI_B5: I.B5 RPI.31(GPIO_06)
NetI_C4: I.C4 J.C4
NetI_HPOA: I.HPOA OSI.A
NetI_HPOB: I.HPOB OSI.B
NetJ_B5: J.B5 RPI.32(GPIO_12)
NetJ_HPOA: J.HPOA OSJ.A
NetJ_HPOB: J.HPOB OSJ.B
NetLPLED_2: LPLED.2 R-LP.1

Not connected: A A6 A7; AUNO 3.3V AREF GND1(GND) GND3(GND) IOREF NC RESET SCL SDA VIN; B A6 A7; C A6 A7; D A6 A7; DISP1 3(CC); E A6 A7; F A6 A7; G A6 A7; H A6 A7; I A6 A7; J A6 A7; RPI 1(3.3V) 2(5V) 6(GND) 7(GPIO_04_(GPIO_GCLK)) 9(GND) 11(GPIO_17_(GPIO_GEN0)) 12(GPIO_18_(GPIO_GEN1)) 13(GPIO_27_(GPIO_GEN2)) 14(GND) 16(GPIO_23_(GPIO_GEN4)) 17(3.3V) 18(GPIO_24_(GPIO_GEN5)) 19(GPIO_10_(SPI_MOSI)) 20(GND) 21(GPIO_09_(SPI_MISO)) 22(GPIO_25_(GPIO_GEN6)) 23(GPIO_11_(SPI_CLK)) 24(GPIO_08_(SPI_CE0_N)) 25(GND) 26(GPIO_07_(SPI_CE1_N)) 27(ID_SD_(I2C_ID_EEPROM)) 28(ID_SC_(I2C_ID_EEPROM)) 30(GND) 39(GND); SR1 7(Q7) 9(Q7) 10(SER)

## Library data of the parts
A B C D E F G H I J = CMP-dgd04bf3j3drhl5uyyz7-14: CONN DIN RCPT 64POS PCB GOLD; Component Kind: Standard; CustomComponentID: dgd04bf3j3drhl5uyyz7; Library Name: CommunityVault
AUNO = CMP-1073962-71: Microcontroller board, Uno [Arduino] A000066 Microcontroller board, Uno 7640152110092; Number of Bits: 8; Component Kind: Standard; Library Name: CommunityVault; Octopart URL: http://octopart.com/a000066-arduino-21222240
CH-A1-CH-A9 CH-B1-CH-B9 CH-C1-CH-C9 CH-D1-CH-D9 CH-E1-CH-E9 CH-F1-CH-F9 CH-G1-CH-G9 CH-H1-CH-H9 CH-I1-CH-I9 CH-J1-CH-J9 = CMP-3894661-5: 2.54mm (.100") Pitch KK® Wire-to-Board Header, Vertical, with Friction Lock, 3 Circuits, Tin (Sn) Plating; Lead-Free Status: Lead Free; Number of Contacts: 4; Component Kind: Standard; Contact Plating: Tin; Housing Color: Natural; Library Name: CommunityVault; Material: Nylon; Number of Positions: 4; Orientation: Vertical; Pin Pitch: 2.54 mm; RoHS: Compliant; Size-Height: 11.7 mm; Size-Length: 10.2 mm
DISP1 = CMP-917f58758bad4eb8-4: Display: LED; 7-segment; 14.22mm; red; 0.9-2.8mcd; cathode; 0.56"; Forward Voltage: 2.10 V; Lead-Free Status: Lead Free; Pin Pitch: 2.54 mm; Component Kind: Standard; Library Name: CommunityVault; Lifecycle Status: Active; Manufacturer Part Number: HDSP-5503; Mounting Style: Through Hole; OctopartID: 917f58758bad4eb8; Octopart URL: http://octopart.com/hdsp-5503-avago-16308; Packaging: Tube; REACH SVHC Compliance: No SVHC; Size-Height: 12.6 mm; Size-Length: 17.0 mm; Size-Width: 12.6 mm
GND OSA OSB OSC OSD OSE OSF OSG OSH OSI OSJ PWR_INPUT = CMP-9ab5517917744572-3: Conn Terminal Block 2 POS 5.08mm Screw ST Cable Mount 16A; Component Kind: Standard; Library Name: CommunityVault; Octopart URL: https://octopart.com/em250502v-cooper+bussmann-46573735; Packaging: Bulk
GND-A GND-B GND-C GND-D GND-E GND-F GND-G GND-H GND-I GND-J GND-MAIN HP-A HP-B HP-C HP-D HP-E HP-F HP-G HP-H HP-I HP-J HP-MAIN LP-A LP-B LP-C LP-D LP-E LP-F LP-G LP-H LP-I LP-J LP-MAIN = CMP-0157c85d593dde90-2: Brass Bright Tin Plate .219 inch High for .109 inch Board Width Turret Terminal; Lead-Free Status: Lead Free; Pin Pitch: 2.77 mm; Component Kind: Standard; Contact Material: Brass; Contact Plating: Tin; Insulation Material: Non-Insulated; Library Name: CommunityVault; Material: Brass; OctopartID: 0157c85d593dde90; Octopart URL: http://octopart.com/1502-2-keystone-32012; RoHS: Compliant; Size-Diameter: 3.17 mm; Size-Length: 2.77 mm
  GND-A GND-B GND-C GND-D GND-E GND-F GND-G GND-H GND-I GND-J HP-A HP-B HP-C HP-D HP-E HP-F HP-G HP-H HP-I HP-J LP-A LP-B LP-C LP-D LP-E LP-F LP-G LP-H LP-I LP-J: Manufacturer Part Number: 1502-2
HPLED LPLED = CMP-ab956297e6cd7452-2: Green 5 mm 60° Diffused 30 mcd 2.2 V Through Hole LED - T-1¾; Manufacturer Part Number: SSL-LX5093GD-5V; Forward Voltage: 5.00 V; Lead-Free Status: Lead Free; Luminous Intensity: 20.0 mcd; Wavelength: 565 nm; Color: Green; Component Kind: Standard; Lens Color: Green; Lens Type: Diffused; Library Name: CommunityVault; Mounting Style: Through Hole; Number of Pins: 2; OctopartID: ab956297e6cd7452; Octopart URL: https://octopart.com/ssl-lx5093gd-5v-lumex-550974; Packaging: Bulk; RoHS: Compliant; Size-Diameter: 5.00 mm; Size-Height: 8.60 mm; Viewing Angle: 60 °
R-HP R-LP = CMP-2034312-2: RES 330 OHM 1/4W 5% CARBON FILM; Lead-Free Status: Lead Free; Component Kind: Standard; Library Name: CommunityVault; Manufacturer Part Number: CF14JT330R; OctopartID: 8faa4785a792506d; Octopart Url: https://octopart.com/cf14jt330r-stackpole+electronics-19205470; Packaging: Tape & Reel (TR); Resistance Tolerance: ±5%; RoHS: Compliant
RPI = CMP-e7ab2d993b99386c-20: Dev Brd, Rpi Model b, Bcm2835 Processor; Component Kind: Standard; Library Name: CommunityVault
SR1 = CMP-384ff145283ee788-6: Shift Register Single 8 Bit Serial Parallel To Serial 16 Pin Plastic DIP Tube; Lead-Free Status: Lead Free; Number of Bits: 8; Output Current: 5.20 mA; Output Current Drive: -1.00 mA; Propagation Delay Max (tpd): 30.0 ns; Supply Voltage (DC): 2.00 V to 6.00 V; Voltage Nodes: 2.00 V, 6.00 V, 5.00 V; Case/Package: PDIP, SOIC; Component Kind: Standard; Library Name: CommunityVault; Lifecycle Status: Active; Mounting Style: Through Hole; OctopartID: 384ff145283ee788; Operating Temperature: 85.0 °C (max); Packaging: Tube; REACH SVHC Compliance: No SVHC; RoHS: Compliant
TMP36-1-TMP36-3 = CMP-2418272-2: Low Voltage Temperature Sensor, 2.7 to 5.5 V Supply, Industrial, 3-pin TO92 (T-3), Tray; Lead-Free Status: Contains Lead; Supply Current: 5e-05 A; Supply Voltage (DC): 5.0 V; Case/Package: TO-92; Component Kind: Standard; Library Name: CommunityVault; Lifecycle Status: Active; Manufacturer Part Number: TMP36GT9; Mounting Style: Through Hole; Number of Pins: 3; OctopartID: ea38233bdfbb35ff; Octopart Url: https://octopart.com/tmp36gt9-analog+devices-594149; RoHS: Non-Compliant
